# Altium schematic sheet [05]Power Supply Tracker.SchDoc
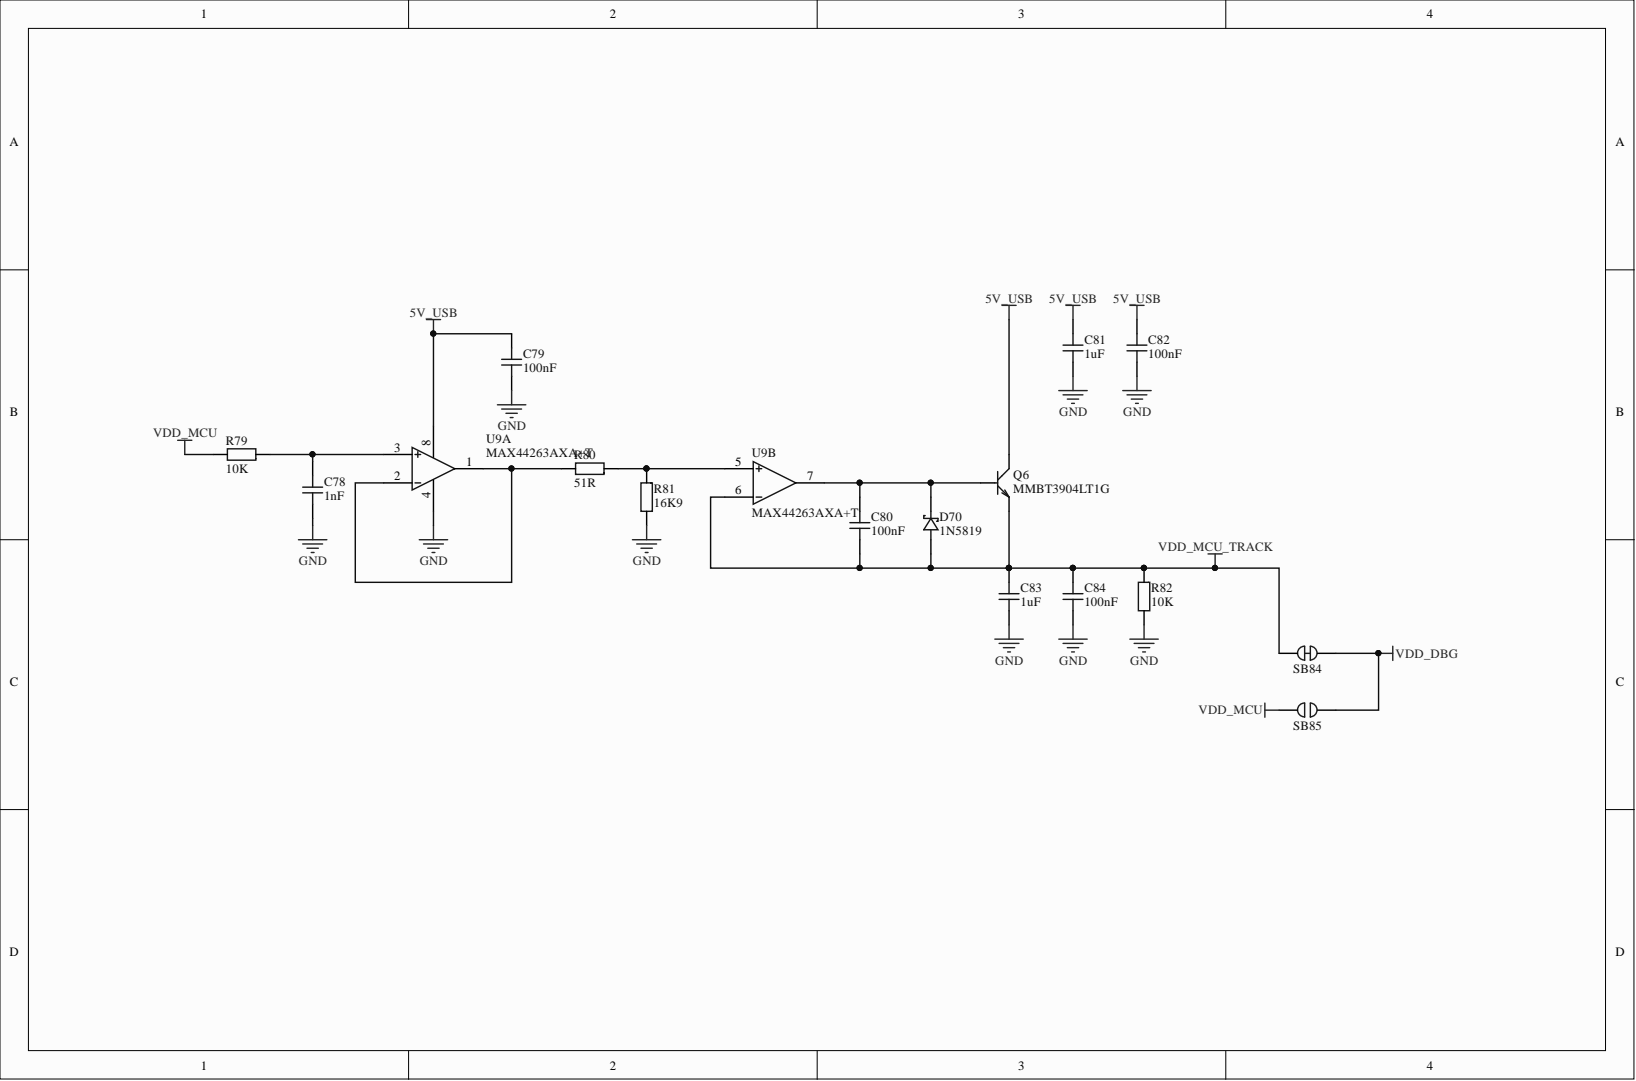
[diagram p0: [05]Power Supply Tracker.SchDoc]

## Parts
C78  1nF  [CAPC1005L]
C79  100nF  [CAPC1005L]
C80  100nF  [CAPC1005L]
C81  1uF  [CAPC1005L]
C82  100nF  [CAPC1005L]
C83  1uF  [CAPC1005L]
C84  100nF  [CAPC1005L]
D70  1N5819  [SOD2512X100L]
Q6  MMBT3904LT1G  [SOT23-3N]
R79  10K  [RESC1005L]
R80  51R  [RESC1005L]
R81  16K9  [RESC1005L]
R82  10K  [RESC1005L]
SB84  SBS  [SBS]  (value not drawn)
SB85  SBO  [SBO]  (value not drawn)  (no BOM)
U9  MAX44263AXA+T  [SC-70-8]  (parts A,B)

## Nets
5V_USB: C79.2 C81.2 C82.2 Q6.3 U9.8
GND: C78.1 C79.1 C81.1 C82.1 C83.1 C84.1 R81.1 R82.1 U9.4
VDD_DBG: SB84.2 SB85.2
VDD_MCU: R79.1 SB85.1
VDD_MCU_TRACK: C80.1 C83.2 C84.2 D70.2 Q6.2 R82.2 SB84.1 U9.6
NetC78_2: C78.2 R79.2 U9.3
NetC80_2: C80.2 D70.1 Q6.1 U9.7
NetR80_1: R80.1 U9.1 U9.2
NetR80_2: R80.2 R81.2 U9.5

## Library data of the parts
C78-C84 = CAP_CHP: Surface Mount Unpolarized Capacitor; Component Kind: Standard; Library Name: Discrete.SchLib
D70 = SKT_SMD: Surface Mount Diode; Component Kind: Standard; Library Name: Discrete.SchLib
Q6 = TRAN_NPN: Surface Mount NPN Transistor; Component Kind: Standard; Library Name: Discrete.SchLib
R79-R82 = RES_CHP: Surface Mount Resistor; Component Kind: Standard; Library Name: Discrete.SchLib
SB84 = SBS: Short-circuit soldering bridge; Component Kind: Net Tie; Library Name: Connector.SchLib
SB85 = SBO: Open-circuit soldering bridge; Library Name: Connector.SchLib
U9 = MAX44263: General Purpose Amplifier 2 Circuit Rail-to-Rail; Component Kind: Standard; Library Name: IC.SchLib
